# Altium schematic sheet PAGE2 - POWER, MCU.SchDoc
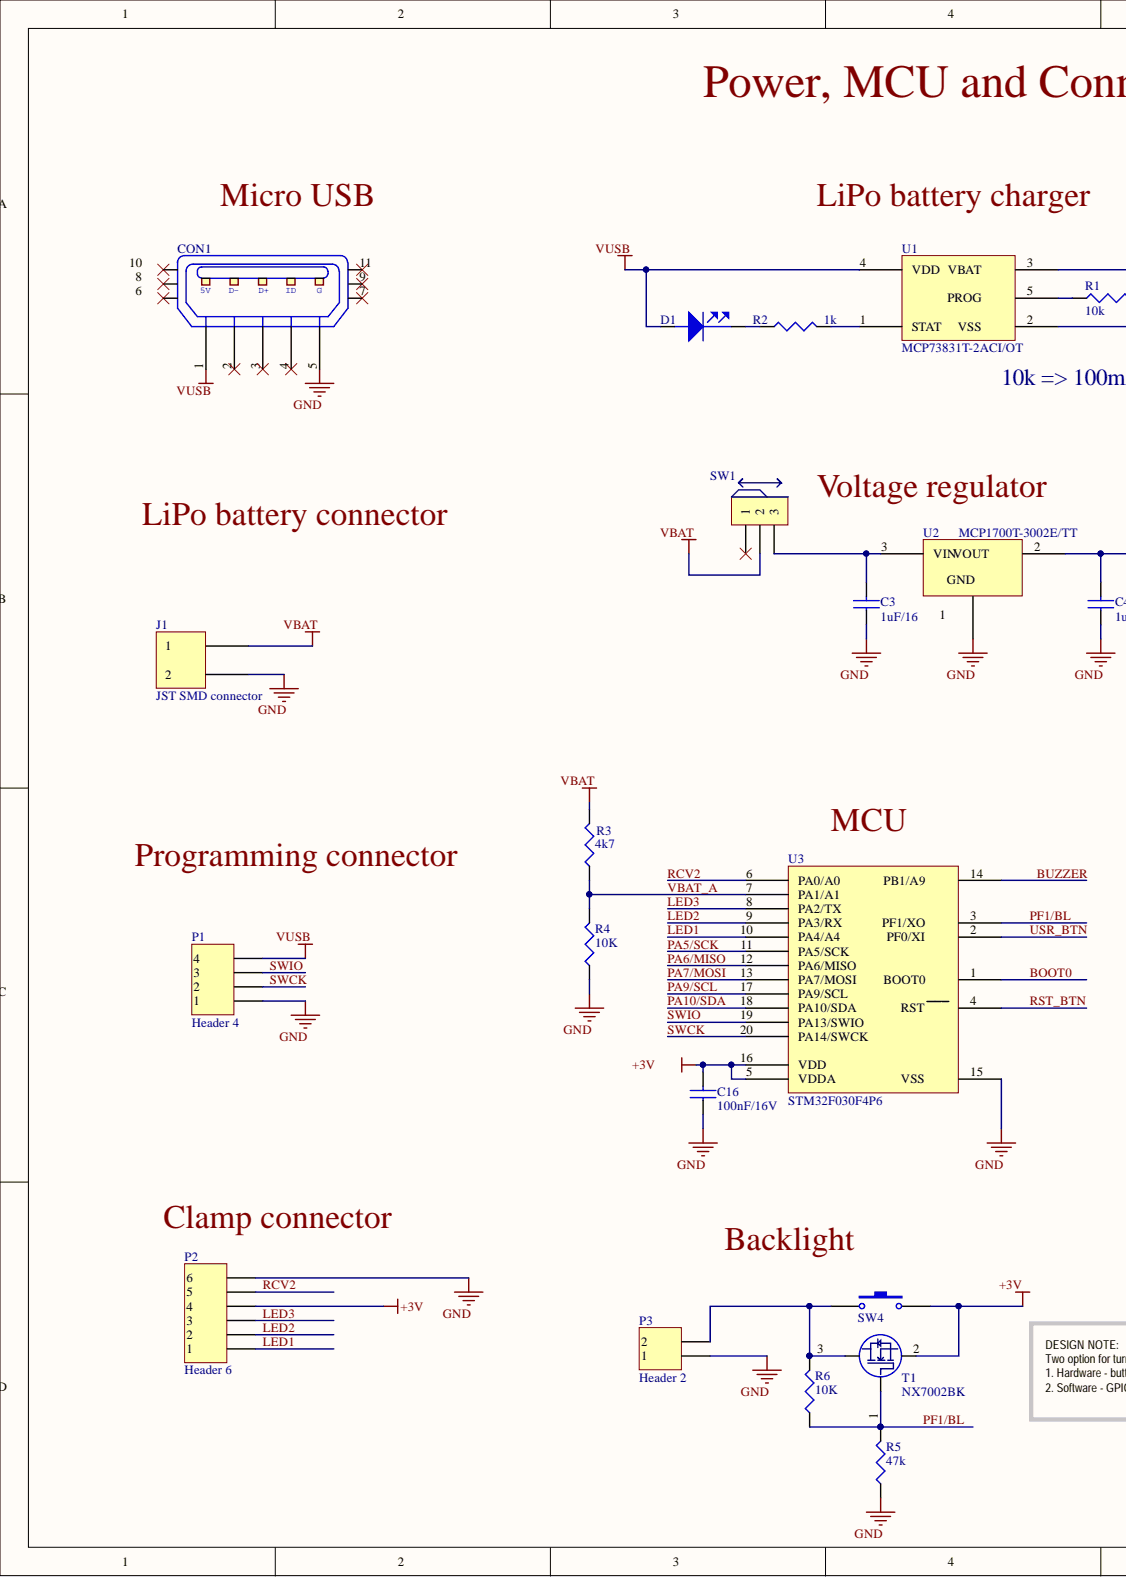
[diagram p0: PAGE2 - POWER, MCU.SchDoc, left]
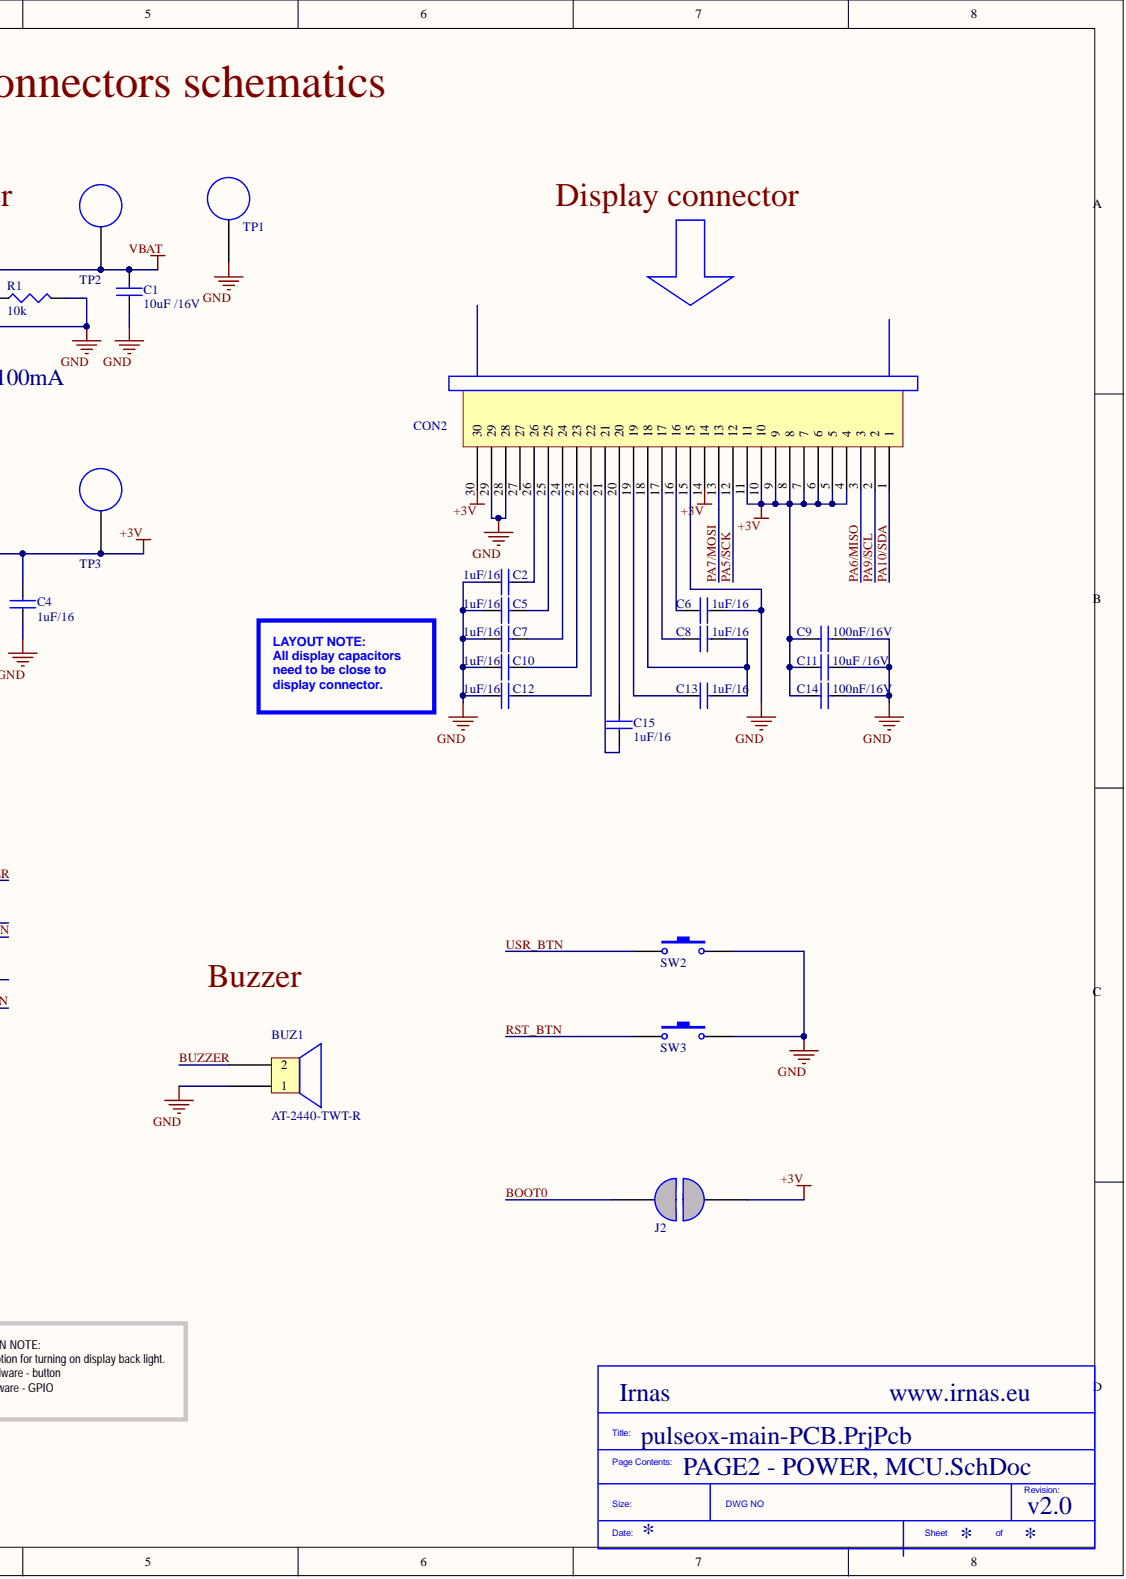
[diagram p1: PAGE2 - POWER, MCU.SchDoc, right]

## Parts
BUZ1  AT-2440-TWT-R  [Buzzer]
C1  10uF /16V  [CAP0805]
C2  1uF/16  [CAP0805]
C3  1uF/16  [CAP0805]
C4  1uF/16  [CAP0805]
C5  1uF/16  [CAP0805]
C6  1uF/16  [CAP0805]
C7  1uF/16  [CAP0805]
C8  1uF/16  [CAP0805]
C9  100nF/16V  [CAP0805]
C10  1uF/16  [CAP0805]
C11  10uF /16V  [CAP0805]
C12  1uF/16  [CAP0805]
C13  1uF/16  [CAP0805]
C14  100nF/16V  [CAP0805]
C15  1uF/16  [CAP0805]
C16  100nF/16V  [CAP0805]
CON1  Micro USB SMD  [10118193]  (value not drawn)
CON2  3-1734592-0  [FPC P0.5mm SMT]  (value not drawn)
D1  LED RED  [LED0603]  (value not drawn)
J1  JST SMD connector  [JST SMD connector]  (no BOM)
J2  Solder jumper  [solder jumper smd]  (value not drawn)  (no BOM)
P1  Header 4  [HDR1X4]
P2  Header 6  [HDR1X6]
P3  Header 2  [HDR1X2]
R1  10k  [RES0603]
R2  1k  [RES0603]
R3  4k7  [RES0805]
R4  10K  [RES0805]
R5  47k  [RES0805]
R6  10K  [RES0805]
SW1  SLIDE SW  [SLIDE_SW]  (value not drawn)
SW2  FSMSM  [FSMSM SMD SW]  (value not drawn)
SW3  FSMSM  [FSMSM SMD SW]  (value not drawn)
SW4  FSMSM  [FSMSM SMD SW]  (value not drawn)
T1  NX7002BK  [SOT23]
TP1  TP  [TP]  (no BOM)
TP2  TP  [TP]  (no BOM)
TP3  TP  [TP]  (no BOM)
U1  MCP73831T-2ACI/OT  [SOT23127P600-8N]
U2  MCP1700T-3002E/TT  [SOT23127P600-8N - duplicate]
U3  STM32F030F4P6  [SOP127P600-8N]

## Nets
+3V: C4.2 C9.1 C11.1 C14.1 C16.2 CON2.4 CON2.5 CON2.6 CON2.7 CON2.8 CON2.9 CON2.10 CON2.11 CON2.14 CON2.30 J2.2 P2.4 SW4.2 T1.2 TP3.1 U2.2 U3.5(VDDA) U3.16(VDD)
GND: BUZ1.1 C1.1 C2.1 C3.1 C4.1 C5.1 C6.2 C7.1 C9.2 C10.1 C11.2 C12.1 C14.2 C16.1 CON1.5 CON2.15 CON2.28 CON2.29 J1.2 P1.1 P2.6 P3.1 R1.2 R4.1 R5.1 SW2.2 SW3.2 TP1.1 U1.2 U2.1 U3.15
VBAT: C1.2 J1.1 R3.2 SW1.2 TP2.1 U1.3
VUSB: CON1.1 D1.2 P1.4 U1.4(VDD)
BOOT0: J2.1 U3.1
BUZZER: BUZ1.2 U3.14
LED1: P2.1 U3.10(PA4/A4)
LED2: P2.2 U3.9(PA3/RX)
LED3: P2.3 U3.8(PA2/TX)
PA5/SCK: CON2.12 U3.11(PA5/SCK)
PA6/MISO: CON2.3 U3.12(PA6/MISO)
PA7/MOSI: CON2.13 U3.13(PA7/MOSI)
PA9/SCL: CON2.2 U3.17(PA9/SCL)
PA10/SDA: CON2.1 U3.18(PA10/SDA)
PF1/BL: R5.2 R6.1 T1.1 U3.3
RCV2: P2.5 U3.6(PA0/A0)
RST_BTN: SW3.1 U3.4
SWCK: P1.2 U3.20(PA14/SWCK)
SWIO: P1.3 U3.19(PA13/SWIO)
USR_BTN: SW2.1 U3.2
VBAT_A: R3.1 R4.2 U3.7(PA1/A1)
NetC2_2: C2.2 CON2.26
NetC3_2: C3.2 SW1.3 U2.3
NetC5_2: C5.2 CON2.25
NetC6_1: C6.1 CON2.16
NetC7_2: C7.2 CON2.24
NetC8_1: C8.1 CON2.17
NetC8_2: C8.2 C13.2 CON2.18
NetC10_2: C10.2 CON2.23
NetC12_2: C12.2 CON2.22
NetC13_1: C13.1 CON2.19
NetC15_1: C15.1 CON2.21
NetC15_2: C15.2 CON2.20
NetD1_1: D1.1 R2.1
NetP3_2: P3.2 R6.2 SW4.1 T1.3
NetR1_1: R1.1 U1.5
NetR2_2: R2.2 U1.1(STAT)

Not connected: CON1 2 3 4 6 7 8 9 10 11; CON2 27; SW1 1

## Library data of the parts
BUZ1 = AT-2440-TWT-R: AUDIO PIEZO TRANSDUCER 1-30V TH; Manufacturer: PUI Audio, Inc.; Current - Supply: 1mA; Driver Circuitry: Transducer, Externally Driven; Frequency: 4kHz; Input Type: Peak-Peak Signal; Operating Mode: Single Tone; Port Location: Top; Ratings: Washable; Sound Pressure Level (SPL): 80dB @ 3V, 10cm; Voltage - Rated: 3V; Voltage Range: 1 ~ 30V; Approvals: -; Category: Audio Products; Component Kind: Standard; ComponentLink1Description: http://www.puiaudio.com/pdf/AT-2440-TWT-R.pdf; ComponentLink1URL: http://www.puiaudio.com/pdf/AT-2440-TWT-R.pdf; Duration: -; Height - Seated (Max): 0.303" (7.70mm); Manufacturer Part Number: AT-2440-TWT-R; Mounting Type: Through Hole; Operating Temperature: -20°C ~ 60°C; Packaging: Tray; Part Status: Active; Pricing 1: 1=1.87, 10=1.7, 100=1.36, 500=1.1288, 1000=0.986, 5000=0.884 (USD); RoHS: RoHS Compliant; Size / Dimension: 0.945" Dia (24.00mm); Stock 1: 3028; Supplier 1: Digi-Key; Supplier Part Number 1: 668-1103-ND; Technology: Piezo; Termination: PC Pins; Library Name: pulseox-main-SCHLib.SchLib
C1 C11 = 10uF /16V: TDK - CGA4J1X5R1C106K125AC - CAP, MLCC, X5R, 10UF, 16V, 0805; Component Kind: Standard; ComponentLink1Description: http://bcove.me/e1v54mtm; ComponentLink1URL: http://bcove.me/e1v54mtm; ComponentLink2Description: http://www.farnell.com/datasheets/1936565.pdf; ComponentLink2URL: http://www.farnell.com/datasheets/1936565.pdf; ComponentLink3Description: http://www.farnell.com/datasheets/1683658.pdf; ComponentLink3URL: http://www.farnell.com/datasheets/1683658.pdf; Library Name: Capacitors.IntLib; Manufacturer: TDK; Manufacturer Part Number: CGA4J1X5R1C106K125AC; Pricing 1: 5=0.41, 50=0.146, 250=0.131, 500=0.12, 1000=0.103 (GBP); RoHS: YES; Stock 1: 8974; Supplier 1: Farnell; Supplier Part Number 1: 2210948RL
C2-C8 C10 C12 C13 C15 = 1uF 16V 0805: MURATA - GRM21BR71C105KA01K - CAP, MLCC, X7R, 1UF, 16V, 0805; Capacitance: 1; Capacitance Tolerance: ± 10%; Ceramic Capacitor Case: 0805 [2012 Metric]; Dielectric Characteristic: X7; Product Range: GRM Series; SVHC: No SVHC (20-Jun-2016; Voltage Rating: 16; Automotive Qualification Standard: -; Component Kind: Standard; ComponentLink1Description: http://www.farnell.com/datasheets/2189141.pdf; ComponentLink1URL: http://www.farnell.com/datasheets/2189141.pdf; Manufacturer: MURATA; Manufacturer Part Number: GRM21BR71C105KA01K; MSL: MSL 1 - Unlimited; Operating Temperature Max: 125; Operating Temperature Min: -55; Packaging: Cut Tape; Pricing 1: 10=0.0623, 100=0.0388, 500=0.0279, 2500=0.0221, 5000=0.0195, 10000=0.0162, 50000=0.0139, 100000=0.0126 (GBP); RoHS: YES; Stock 1: 18829; Supplier 1: Farnell; Supplier Part Number 1: 2688536; Library Name: pulseox-main-SCHLib.SchLib
C9 C14 C16 = 100nF 16V 0805: MURATA - GRM219R71C104KA01D - CAP, MLCC, X7R, 0.1UF, 16V, 0805; Capacitance: 0.1; Capacitance Tolerance: ± 10%; Ceramic Capacitor Case: 0805 [2012 Metric]; Dielectric Characteristic: X7; Product Range: GRM Series; SVHC: No SVHC (17-Dec-2015; Voltage Rating: 16; Automotive Qualification Standard: -; Component Kind: Standard; ComponentLink1Description: http://www.farnell.com/datasheets/2079178.pdf; ComponentLink1URL: http://www.farnell.com/datasheets/2079178.pdf; ComponentLink2Description: http://www.farnell.com/datasheets/1935878.pdf; ComponentLink2URL: http://www.farnell.com/datasheets/1935878.pdf; Manufacturer: MURATA; Manufacturer Part Number: GRM219R71C104KA01D; MSL: MSL 1 - Unlimited; Operating Temperature Max: 125; Operating Temperature Min: -55; Packaging: Cut Tape; Pricing 1: 10=0.0517, 100=0.0335, 500=0.0221, 1000=0.02, 2000=0.0175, 4000=0.0134, 20000=0.0095, 40000=0.0081 (GBP); RoHS: YES; Stock 1: 4286; Supplier 1: Farnell; Supplier Part Number 1: 2611934; Library Name: pulseox-main-SCHLib.SchLib
CON1 = Micro USB SMD: AMPHENOL FCI - 10104110-0001LF - MICRO USB, 2.0 TYPE B, RECEPTACLE, SMT; Connector Mounting: Surface Mount; Connector Type: USB Micro B; Contact Termination: Surface Mount Right Angle; No. of Contacts: 5Contacts<!--FANDB--><div class="features-and-benefits-container"><div class="features-and-benefits-description"><span class="features-benefits-value">The 10104110-0001LF is a micro-USB type-B Receptacle Connector, comply with physical, electrical and environmental requirements of micro-USB standard (USB 2.0). Space receptacles and plugs are available in a wide variety of mounting and termination configurations, permitting their use in various applications. Compared with the standard mini-B connectors, the size is reduced by approximately 60% while still allowing a high-speed data transfer of 480; No. of Positions: 5; SVHC: No SVHC (15-Jun-2015); USB Connector Type: Micro USB Type B; USB Standard: USB 2.0; Component Kind: Standard; ComponentLink1Description: http://www.farnell.com/datasheets/1469072.pdf; ComponentLink1URL: http://www.farnell.com/datasheets/1469072.pdf; Contact Material: Copper Alloy; Contact Plating: Gold Plated Contacts; Contact Termination Type: Surface Mount; Gender: Receptacle; Library Name: USB_Connector_Lib_20150530.IntLib; Manufacturer: AMPHENOL FCI; Manufacturer Part Number: 10104110-0001LF; Orientation: Right Angle; Pricing 1: 1=0.464, 10=0.451, 25=0.437, 50=0.424, 100=0.41, 250=0.291 (GBP); Product Range: -; RoHS: YES; Stock 1: 25585; Supplier 1: Farnell; Supplier Part Number 1: 2293753
CON2 = 3-1734592-0: AMP - TE CONNECTIVITY - 3-1734592-0 - CONNECTOR, FFC / FPC, 0.5MM, 30WAY; Component Kind: Standard; ComponentLink1Description: http://www.tycoelectronics.com/commerce/DocumentDelivery/DDEController?Action=srchrtrv&DocNm=CVM_3-1734592-0&DocType=Customer+View+Model&DocLang=3D; ComponentLink1URL: http://www.tycoelectronics.com/commerce/DocumentDelivery/DDEController?Action=srchrtrv&DocNm=CVM_3-1734592-0&DocType=Customer+View+Model&DocLang=3D; ComponentLink2Description: http://www.farnell.com/cad/1650059.pdf; ComponentLink2URL: http://www.farnell.com/cad/1650059.pdf; ComponentLink3Description: http://www.farnell.com/datasheets/586796.pdf; ComponentLink3URL: http://www.farnell.com/datasheets/586796.pdf; Library Name: FFC-FPC board connectors.IntLib; Manufacturer: AMP - TE CONNECTIVITY; Manufacturer Part Number: 3-1734592-0; Pricing 1: 1=0.616, 25=0.557, 100=0.485, 250=0.442, 500=0.399 (GBP); RoHS: YES; Supplier 1: Farnell; Supplier Part Number 1: 1816393
D1 = LED RED: BROADCOM LIMITED - HSMS-C190 - LED, RED, 10MCD, 626NM; Forward Current If: 20; Forward Voltage: 2.1; LED Mounting: SMD; Lens Shape: Rectangular; Luminous Intensity: 10; SVHC: No SVHC (17-Dec-2015)<!--FANDB--><div class="features-and-benefits-container"><div class="features-and-benefits-description"><span class="features-benefits-value">The HSMS-C190 is a HER SMD Chip LED with untinted/diffused lens, made of GaP. It is designed in an industry standard package 1.6 x 0.8mm footprint with 0.8mm profile. It is compatible with IR reflow solder processes. The small size and wide viewing angle make this LED prime choices for backlighting applications and front panel illumination especially where space is a premium.</span></div><div class="features-and-benefits-list"><ul class="features-and-benefits-list-list"><li class="features-and-benefits-list-item">Small size</li><li class="features-and-benefits-list-item">Industry standard footprint</li><li class="features-and-benefits-list-item">Compatible with IR solder</li><li class="features-and-benefits-list-item">Diffused optics</li><li class="features-and-benefits-list-item">-40 to 85; Viewing Angle: 170°; Wavelength Typ: 626; Automotive Qualification Standard: -; Bulb Size: -; Component Kind: Standard; ComponentLink1Description: http://www.farnell.com/datasheets/313031.pdf; ComponentLink1URL: http://www.farnell.com/datasheets/313031.pdf; ComponentLink2Description: http://www.farnell.com/datasheets/1681524.pdf; ComponentLink2URL: http://www.farnell.com/datasheets/1681524.pdf; ComponentLink3Description: http://www.farnell.com/datasheets/2165483.pdf; ComponentLink3URL: http://www.farnell.com/datasheets/2165483.pdf; LED Colour: Red; Library Name: Diodes.IntLib; Manufacturer: BROADCOM LIMITED; Manufacturer Part Number: HSMS-C190; MSL: -; Packaging: Cut Tape; Pricing 1: 1=0.361, 10=0.256, 50=0.235, 100=0.106, 200=0.101 (GBP); Product Range: -; RoHS: YES; Stock 1: 7534; Supplier 1: Farnell; Supplier Part Number 1: 2497356
P1 = Header 4: Header, 4-Pin; Component Kind: Standard; LatestRevisionDate: 17-Jul-2002; LatestRevisionNote: Re-released for DXP Platform.; Library Name: Miscellaneous Connectors.IntLib; Signal Integrity: Connector; Publisher: Altium Limited
P2 = Header 6: Header, 6-Pin; Component Kind: Standard; LatestRevisionDate: 17-Jul-2002; LatestRevisionNote: Re-released for DXP Platform.; Library Name: Miscellaneous Connectors.IntLib; Signal Integrity: Connector; Publisher: Altium Limited
P3 = Header 2: Header, 2-Pin; Component Kind: Standard; LatestRevisionDate: 17-Jul-2002; LatestRevisionNote: Re-released for DXP Platform.; Library Name: Miscellaneous Connectors.IntLib; Signal Integrity: Connector; Publisher: Altium Limited
R1 = 10k: MULTICOMP - MCMR06X103 JTL - RES, CERAMIC, 10K, 5%, 0.1W, 0603; Automotive Qualification Standard: -<!--FANDB--><div class="features-and-benefits-container"><div class="features-and-benefits-description"><span class="features-benefits-value">The Multicomp MCMR series resistors are automotive grade, anti sulphured thick film chip resistor. The resistance range includes E24 and E96 decade values from 1ohm to 10Mohm. These resistors are constructed on top of a high grade ceramic body.</span></div><div class="features-and-benefits-list"><ul class="features-and-benefits-list-list"><li class="features-and-benefits-list-item">EIA 0402, 0603, 0805, 1206 chip sizes available</li><li class="features-and-benefits-list-item">High reliability and stability</li><li class="features-and-benefits-list-item">Automotive grade AEC-Q200 compliant</li><li class="features-and-benefits-list-item">Complaint with (IEC 60068-1) 55/155/56 climatic category</li><li class="features-and-benefits-list-item">Maximum operation voltage of 50V, 75V, 150V and 200V</li><li class="features-and-benefits-list-item">Power rating of 62.5mW, 100mW, 125mW and 250mW</li><li class="features-and-benefits-list-item">Available in ±1% and ±5% tolerance</li><li class="features-and-benefits-list-item">Temperature coefficient (TCR) of +200ppm/°C, +100ppm/°C and -200ppm/°C to +400ppm/°C</li><li class="features-and-benefits-list-item">Operating temperature range -55°C to 155; Power Rating: 100; Product Range: MCMR Series; Resistance: 10; Resistor Case Style: 0603 [1608 Metric]; Temperature Coefficient: ± 100; Voltage Rating: 75; Component Kind: Standard; ComponentLink1Description: http://www.farnell.com/datasheets/1716707.pdf; ComponentLink1URL: http://www.farnell.com/datasheets/1716707.pdf; Library Name: Resistors.IntLib; Manufacturer: MULTICOMP; Manufacturer Part Number: MCMR06X103 JTL; Packaging: Cut Tape; Pricing 1: 10=0.0087, 100=0.0074, 500=0.0061, 1000=0.0048, 2500=0.0032, 5000=0.0024, 25000=0.0016, 50000=0.0013 (GBP); Resistance Tolerance: ± 5%; Resistor Element Material: Ceramic; RoHS: Y-EX; Stock 1: 19791; Supplier 1: Farnell; Supplier Part Number 1: 2073356
R2 = 1k: MULTICOMP - MCMR06X102 JTL - RES, CERAMIC, 1K, 5%, 0.1W, 0603; Power Rating: 100; Product Range: MCMR Series; Resistance: 1; Resistor Case Style: 0603 [1608 Metric]; Resistor Mounting: Surface Mount Device<!--FANDB--><div class="features-and-benefits-container"><div class="features-and-benefits-description"><span class="features-benefits-value">The Multicomp MCMR series resistors are automotive grade, anti sulphured thick film chip resistor. The resistance range includes E24 and E96 decade values from 1ohm to 10Mohm. These resistors are constructed on top of a high grade ceramic body.</span></div><div class="features-and-benefits-list"><ul class="features-and-benefits-list-list"><li class="features-and-benefits-list-item">EIA 0402, 0603, 0805, 1206 chip sizes available</li><li class="features-and-benefits-list-item">High reliability and stability</li><li class="features-and-benefits-list-item">Automotive grade AEC-Q200 compliant</li><li class="features-and-benefits-list-item">Complaint with (IEC 60068-1) 55/155/56 climatic category</li><li class="features-and-benefits-list-item">Maximum operation voltage of 50V, 75V, 150V and 200V</li><li class="features-and-benefits-list-item">Power rating of 62.5mW, 100mW, 125mW and 250mW</li><li class="features-and-benefits-list-item">Available in ±1% and ±5% tolerance</li><li class="features-and-benefits-list-item">Temperature coefficient (TCR) of +200ppm/°C, +100ppm/°C and -200ppm/°C to +400ppm/°C</li><li class="features-and-benefits-list-item">Operating temperature range -55°C to 155; Temperature Coefficient: ± 100; Voltage Rating: 75; Automotive Qualification Standard: -; Component Kind: Standard; ComponentLink1Description: http://www.farnell.com/datasheets/1716707.pdf; ComponentLink1URL: http://www.farnell.com/datasheets/1716707.pdf; Library Name: Resistors.IntLib; Manufacturer: MULTICOMP; Manufacturer Part Number: MCMR06X102 JTL; Packaging: Cut Tape; Pricing 1: 10=0.0089, 100=0.0076, 500=0.0063, 1000=0.0049, 2500=0.0033, 5000=0.0024, 25000=0.0016, 50000=0.0013 (GBP); Resistance Tolerance: ± 5%; Resistor Element Material: Ceramic; RoHS: Y-EX; Stock 1: 18133; Supplier 1: Farnell; Supplier Part Number 1: 2073354
R3 = 4k7 1% 0805: VISHAY - CRCW08054K70FKEA - RES, THICK FILM, 4K7, 1%, 0.125W, 0805; Automotive Qualification Standard: AEC-Q200; Power Rating: 125; Product Range: CRCW Series; Resistance: 4.7; Resistor Case Style: 0805 [2012 Metric]; Resistor Mounting: Surface Mount Device<!--FANDB--><div class="features-and-benefits-container"><div class="features-and-benefits-description"><span class="features-benefits-value">The Vishay D/CRCW e3 series are standard thick film chip resistors. The resistance range includes E96 and E24 decade values from 1ohm to 10Mohm. CRCW e3 series resistors have a pure tin solder contacts on the Ni barrier layer that provides compatibility with reflow soldering process.</span></div><div class="features-and-benefits-list"><ul class="features-and-benefits-list-list"><li class="features-and-benefits-list-item">Automotive grade AEC-Q200 qualified</li><li class="features-and-benefits-list-item">EIA 0402, 0603, 0805, 1206, 1210, 1218, 2010, 2512 standard case sizes available</li><li class="features-and-benefits-list-item">Stability &Delta; Temperature Coefficient: ± 100; Voltage Rating: 150; Component Kind: Standard; ComponentLink1Description: http://www.vishay.com/docs/20014/smdpack.pdf; ComponentLink1URL: http://www.vishay.com/docs/20014/smdpack.pdf; ComponentLink2Description: http://www.farnell.com/datasheets/2310790.pdf; ComponentLink2URL: http://www.farnell.com/datasheets/2310790.pdf; ComponentLink3Description: http://www.farnell.com/cad/1552998.zip; ComponentLink3URL: http://www.farnell.com/cad/1552998.zip; Manufacturer: VISHAY; Manufacturer Part Number: CRCW08054K70FKEA; MSL: MSL 1 - Unlimited; Packaging: Cut Tape; Pricing 1: 10=0.0557, 100=0.0223, 500=0.0187, 1000=0.0086, 2500=0.0064 (GBP); Resistance Tolerance: ± 1%; Resistor Element Material: Thick Film; RoHS: Y-EX; Stock 1: 3035; Supplier 1: Farnell; Supplier Part Number 1: 1469923; Library Name: pulseox-main-SCHLib.SchLib
R4 R6 = 10K 1% 0805_1: WALSIN - WR08X1002FTL - RES, THICK FILM, 10K, 1%, 150V, 0805; Power Rating: 125; Product Range: WR08 Series; Resistance: 10; Resistor Case Style: 0805 [2012 Metric]; Temperature Coefficient: ± 100; Voltage Rating: 150; Automotive Qualification Standard: -; Component Kind: Standard; ComponentLink1Description: http://www.farnell.com/datasheets/1960319.pdf; ComponentLink1URL: http://www.farnell.com/datasheets/1960319.pdf; Manufacturer: WALSIN; Manufacturer Part Number: WR08X1002FTL; MSL: MSL 2 - 1 year<!--FANDB--><div class="features-and-benefits-container"><div class="features-and-benefits-description"><span class="features-benefits-value">The WR08 series resistors from Walsin are thick film general purpose chip resistors. These resistors are constructed in a high grade ceramic body (aluminium oxide).</span></div><div class="features-and-benefits-list"><ul class="features-and-benefits-list-list"><li class="features-and-benefits-list-item">Resistance range includes E24 and E96 decade values from 1ohm to 10Mohm</li><li class="features-and-benefits-list-item">EIA 0805 case size</li><li class="features-and-benefits-list-item">Available in ?±100ppm/°C and -200ppm/°C to +400ppm/°C TCR values</li><li class="features-and-benefits-list-item">Available in ±1% and ±5% tolerance</li><li class="features-and-benefits-list-item">High reliability and stability</li><li class="features-and-benefits-list-item">Higher component and equipment reliability</li><li class="features-and-benefits-list-item">Lower assembly costs</li><li class="features-and-benefits-list-item">Continuous working voltage is 150V and rated power is 125; Packaging: Cut Tape; Pricing 1: 10=0.0208, 100=0.0069, 500=0.0042, 1000=0.003, 2500=0.0023 (GBP); Resistance Tolerance: ± 1%; Resistor Element Material: Thick Film; RoHS: YES; Stock 1: 45511; Supplier 1: Farnell; Supplier Part Number 1: 2502671; Library Name: pulseox-main-SCHLib.SchLib
R5 = 47k 1% 0805: WELWYN - WCR0805-47KFI - RES, THICK FILM, 47K, 1%, 0.125W, 0805; Automotive Qualification Standard: AEC-Q200; External Depth: 0.55; External Length / Height: 2; External Width: 1.25; Insulation Resistance: 1000; Power Rating: 125; Product Range: WCR Series; Proof Voltage: 500; Reel Quantity: 5000; Resistance: 47; Resistor Case Style: 0805 [2012 Metric]; Resistor Mounting: Surface Mount Device; SVHC: No SVHC (15-Jun-2015); Temperature Coefficient: ± 100; Voltage Rating: 150; Component Kind: Standard; ComponentLink1Description: http://www.farnell.com/datasheets/2331353.pdf; ComponentLink1URL: http://www.farnell.com/datasheets/2331353.pdf; Manufacturer: WELWYN; Manufacturer Part Number: WCR0805-47KFI; No. of Pins: 2; Operating Temperature Max: 155; Operating Temperature Min: -55; Operating Temperature Range: -55°C to +155; Packaging: Cut Tape; Pricing 1: 10=0.0208, 100=0.0156, 500=0.0115, 1000=0.01, 2500=0.0088 (GBP); Resistance Tolerance: ± 1%; Resistor Element Material: Thick Film; RoHS: YES; Stock 1: 26620; Supplier 1: Farnell; Supplier Part Number 1: 1099812; Tape Width: 8; Library Name: pulseox-main-SCHLib.SchLib
SW1 = SLIDE SW: C & K COMPONENTS - OS102011MA1QS1 - SWITCH SLIDE SHORTING SPDT; Contact Current Max: 100; Contact Voltage DC Max: 12; SVHC: No SVHC (20-Jun-2016)<!--FANDB--><div class="features-and-benefits-container"><div class="features-and-benefits-description"><span class="features-benefits-value">The OS102011MA1QS1 is a Miniature Slide Switch features a new high-temperature option for lead-free soldering. Designed with a variety of actuators and terminations, the miniature slide switches are ideal for thermostat applications, as well as test and measurement equipment, computer peripherals and consumer products.</span></div><div class="features-and-benefits-list"><ul class="features-and-benefits-list-list"><li class="features-and-benefits-list-item">SPDT Contact Configuration</li><li class="features-and-benefits-list-item">Variety of Actuators and Terminations</li><li class="features-and-benefits-list-item">PC and Panel Mounting</li><li class="features-and-benefits-list-item">Silver Contact Plating</li><li class="features-and-benefits-list-item">Shorting Contacts</li><li class="features-and-benefits-list-item">Halogen-free Material</li></ul></div><div class="features-and-benefits-applications"><ul class="features-and-benefits-value"><li class="features-and-benefits-list-item">Test & Measurement</li><li class="features-and-benefits-list-item">Computers & Computer Peripherals</li><li class="features-and-benefits-list-item">Communications & Networking</li><li class="features-and-benefits-list-item">Consumer Electronics</li></ul></div></div>; Switch Mounting: Through Hole; Switch Operation: On-On; Component Kind: Standard; ComponentLink1Description: http://www.farnell.com/datasheets/1839844.pdf; ComponentLink1URL: http://www.farnell.com/datasheets/1839844.pdf; Contact Configuration: SPDT; Contact Voltage AC Max: -; Manufacturer: C & K COMPONENTS; Manufacturer Part Number: OS102011MA1QS1; Orientation: Right Angle; Pricing 1: 1=0.3, 75=0.251, 150=0.244, 250=0.2, 500=0.196, 1500=0.192, 2500=0.188, 5000=0.184 (GBP); Product Range: -; RoHS: YES; Stock 1: 1781; Supplier 1: Farnell; Supplier Part Number 1: 2435103; Library Name: pulseox-main-SCHLib.SchLib
SW2-SW4 = FSMSM: TE CONNECTIVITY / ALCOSWITCH - FSMSM - SWITCH, SPST, 0.05A, 24VDC, SMD; Actuation Type: Raised; Contact Configuration: SPST<!--FANDB--><div class="features-and-benefits-container"><div class="features-and-benefits-description"><span class="features-benefits-value">The FSMSM is a single-pole single-throw 4.5; Contact Current Max: 50; Contact Voltage DC Nom: 24; Illumination: Non Illuminated; Operating Force: 180; Product Range: FSMSM Series; Component Kind: Standard; ComponentLink1Description: http://www.farnell.com/datasheets/1845752.pdf; ComponentLink1URL: http://www.farnell.com/datasheets/1845752.pdf; ComponentLink2Description: http://www.farnell.com/cad/1885096.pdf; ComponentLink2URL: http://www.farnell.com/cad/1885096.pdf; ComponentLink3Description: http://www.farnell.com/datasheets/1754085.pdf; ComponentLink3URL: http://www.farnell.com/datasheets/1754085.pdf; Manufacturer: TE CONNECTIVITY / ALCOSWITCH; Manufacturer Part Number: FSMSM; Pricing 1: 1=0.157, 75=0.148, 150=0.144, 250=0.139, 500=0.102, 1500=0.1, 2500=0.0979, 5000=0.0959 (GBP); RoHS: YES; Stock 1: 14353; Supplier 1: Farnell; Supplier Part Number 1: 1703878; Switch Terminals: -; Library Name: pulseox-main-SCHLib.SchLib
T1 = NX7002BK: NEXPERIA - NX7002BK - MOSFET, N-CH, 60V, 0.27A, SOT-23-3; Continuous Drain Current Id: 270; Drain Source Voltage Vds: 60; No. of Pins: 3; On Resistance Rds(on): 2.2; Power Dissipation Pd: 310; Rds(on) Test Voltage Vgs: 10; SVHC: No SVHC (17-Dec-2015; Threshold Voltage Vgs: 1.6; Transistor Case Style: SOT-23; Transistor Polarity: N Channel; Automotive Qualification Standard: -; Component Kind: Standard; ComponentLink1Description: http://www.farnell.com/datasheets/1970559.pdf; ComponentLink1URL: http://www.farnell.com/datasheets/1970559.pdf; Manufacturer: NEXPERIA; Manufacturer Part Number: NX7002BK; MSL: MSL 1 - Unlimited; Operating Temperature Max: 150; Pricing 1: 1=0.0468, 25=0.0379, 100=0.0268, 250=0.0233, 500=0.0212, 1000=0.0189 (GBP); Product Range: -; RoHS: YES; Stock 1: 2195; Supplier 1: Farnell; Supplier Part Number 1: 2469640; Library Name: pulseox-main-SCHLib.SchLib
U1 = MCP73831T-2ACI/OT: MICROCHIP - MCP73831T-2ACI/OT - LI-ION/LI-POLY CHARGE CONTROLLER; Component Kind: Standard; ComponentLink1Description: http://www.farnell.com/datasheets/2124927.pdf; ComponentLink1URL: http://www.farnell.com/datasheets/2124927.pdf; ComponentLink2Description: http://www.farnell.com/cad/1545964.zip; ComponentLink2URL: http://www.farnell.com/cad/1545964.zip; Manufacturer: MICROCHIP; Manufacturer Part Number: MCP73831T-2ACI/OT; Pricing 1: 1=0.476, 10=0.407, 25=0.385, 50=0.361, 100=0.336 (GBP); RoHS: YES; Stock 1: 39052; Supplier 1: Farnell; Supplier Part Number 1: 1332158; Library Name: pulseox-main-SCHLib.SchLib
U2 = MCP1700T-3002E/TT: MICROCHIP - MCP1700T-3002E/TT - IC, LDO REGULATOR, 0.25A, 6V, SOT23-3; Component Kind: Standard; ComponentLink1Description: http://www.farnell.com/datasheets/1784514.pdf; ComponentLink1URL: http://www.farnell.com/datasheets/1784514.pdf; ComponentLink2Description: http://www.farnell.com/cad/1545350.zip; ComponentLink2URL: http://www.farnell.com/cad/1545350.zip; ComponentLink3Description: http://www.farnell.com/datasheets/2289014.pdf; ComponentLink3URL: http://www.farnell.com/datasheets/2289014.pdf; Manufacturer: MICROCHIP; Manufacturer Part Number: MCP1700T-3002E/TT; Pricing 1: 1=0.323, 10=0.296, 25=0.272, 50=0.247, 100=0.224 (GBP); RoHS: YES; Stock 1: 35318; Supplier 1: Farnell; Supplier Part Number 1: 1331482; Library Name: pulseox-main-SCHLib.SchLib
U3 = STM32F030F4P6: STMICROELECTRONICS - STM32F030F4P6 - MCU, 32BIT, CORTEX-M0, 48MHZ, TSSOP-20; Architecture: ARM Cortex-M0; CPU Speed: 48; Embedded Interface Type: I2; MCU Case Style: TSSOP; No. of Bits: 32; No. of I/O's: 15; No. of Pins: 20; Product Range: STM32 F0 ARM Cortex-M0 Microcontrollers; Program Memory Size: 16; RAM Memory Size: 4; Supply Voltage Max: 3.6; Supply Voltage Min: 2.4; SVHC: No SVHC (17-Dec-2015)<!--FANDB--><div class="features-and-benefits-container"><div class="features-and-benefits-description"><span class="features-benefits-value">The STM32F030F4P6 is a Microcontroller Unit incorporates the high-performance ARM Cortex -M0 32-bit RISC core operating at a 48MHz frequency, high-speed embedded memories and an extensive range of enhanced peripherals and I/Os. All devices offer standard communication interfaces, one 12-bit ADC, seven general-purpose 16-bit timers and an advanced-control PWM timer.</span></div><div class="features-and-benefits-list"><ul class="features-and-benefits-list-list"><li class="features-and-benefits-list-item">CRC calculation unit</li><li class="features-and-benefits-list-item">4 to 32MHz Crystal oscillator</li><li class="features-and-benefits-list-item">32kHz Oscillator for RTC with calibration</li><li class="features-and-benefits-list-item">Internal 8MHz RC with x6 PLL option</li><li class="features-and-benefits-list-item">Internal 40kHz RC oscillator</li><li class="features-and-benefits-list-item">0 to 3.6V Conversion range</li><li class="features-and-benefits-list-item">2.4 to 3.6; Component Kind: Standard; ComponentLink1Description: http://www.farnell.com/datasheets/1883133.pdf; ComponentLink1URL: http://www.farnell.com/datasheets/1883133.pdf; ComponentLink2Description: http://www.farnell.com/datasheets/2267119.pdf; ComponentLink2URL: http://www.farnell.com/datasheets/2267119.pdf; Library Name: STM_MCU.IntLib; Manufacturer: STMICROELECTRONICS; Manufacturer Part Number: STM32F030F4P6; MSL: MSL 3 - 168 hours; Packaging: Each; Pricing 1: 1=1.21, 10=0.803, 100=0.703, 500=0.491, 2500=0.425 (GBP); RoHS: YES; Stock 1: 4618; Supplier 1: Farnell; Supplier Part Number 1: 2393635
J1 = JST SMD connector: Library Name: pulseox-main-SCHLib.SchLib
J2 = Solder jumper: Library Name: pulseox-main-SCHLib.SchLib
TP1-TP3 = TP: Library Name: pulseox-main-SCHLib.SchLib
